# Altium schematic sheet Sensors.SchDoc(Sensors)
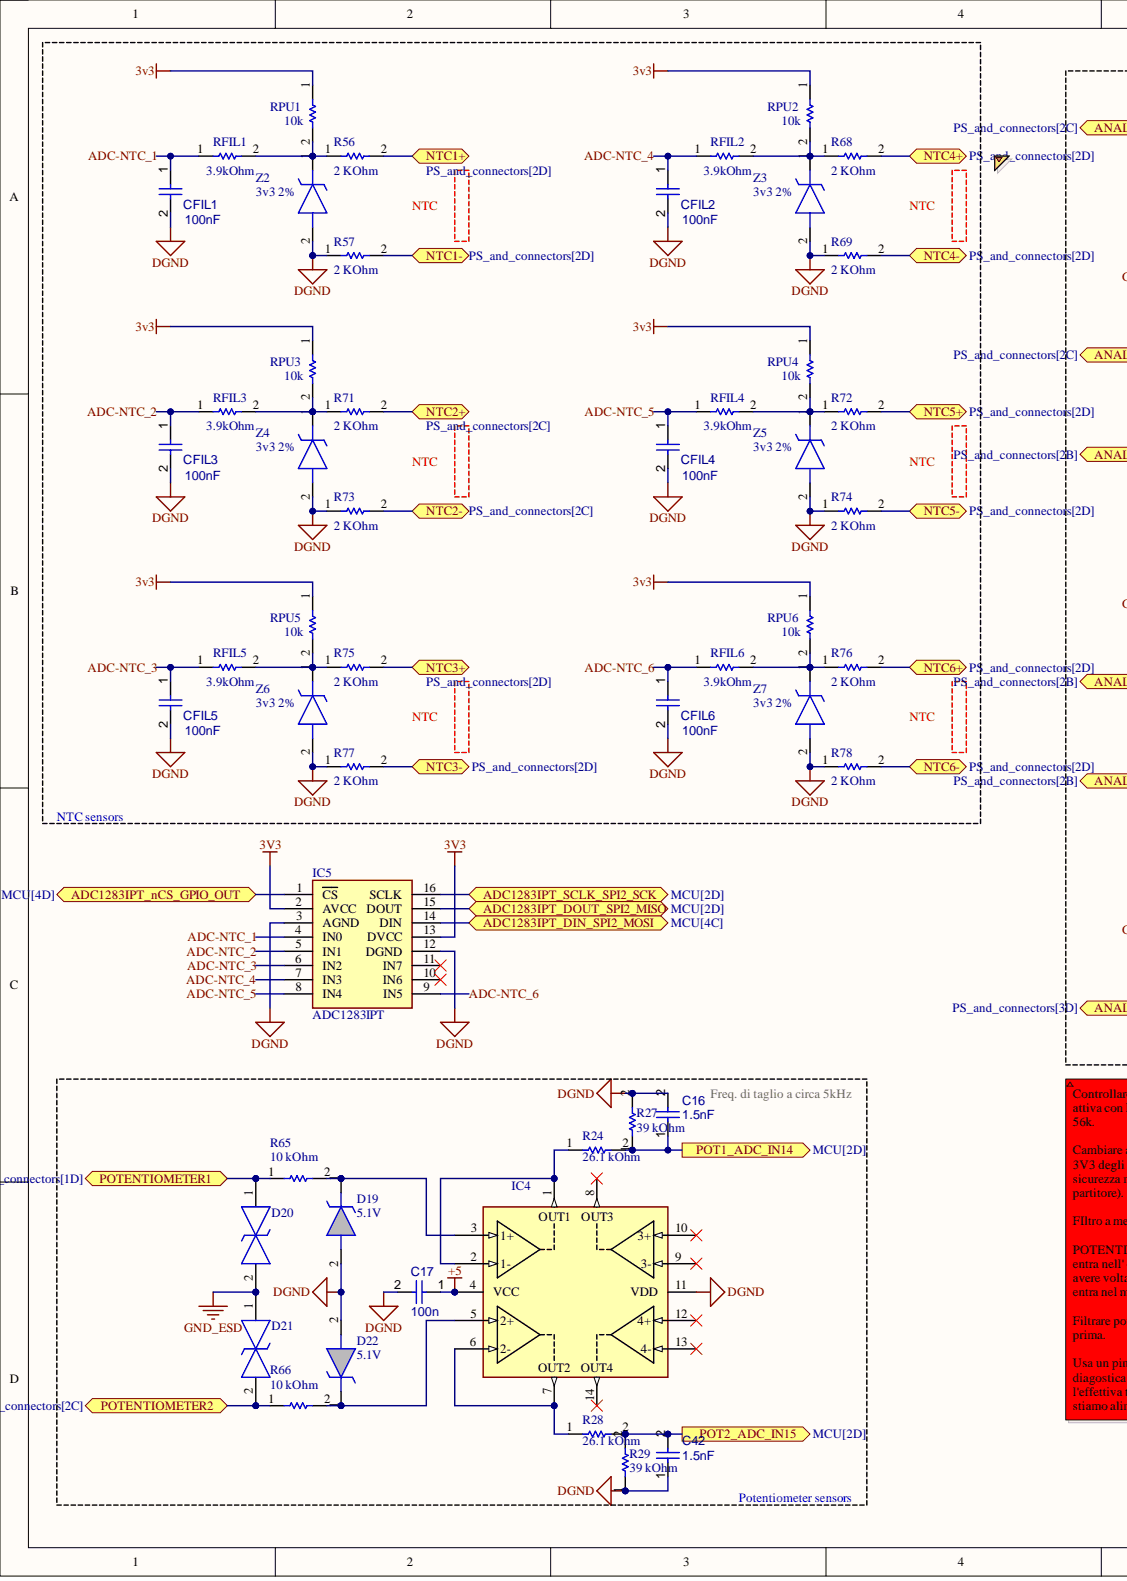
[diagram p0: Sensors.SchDoc, left]
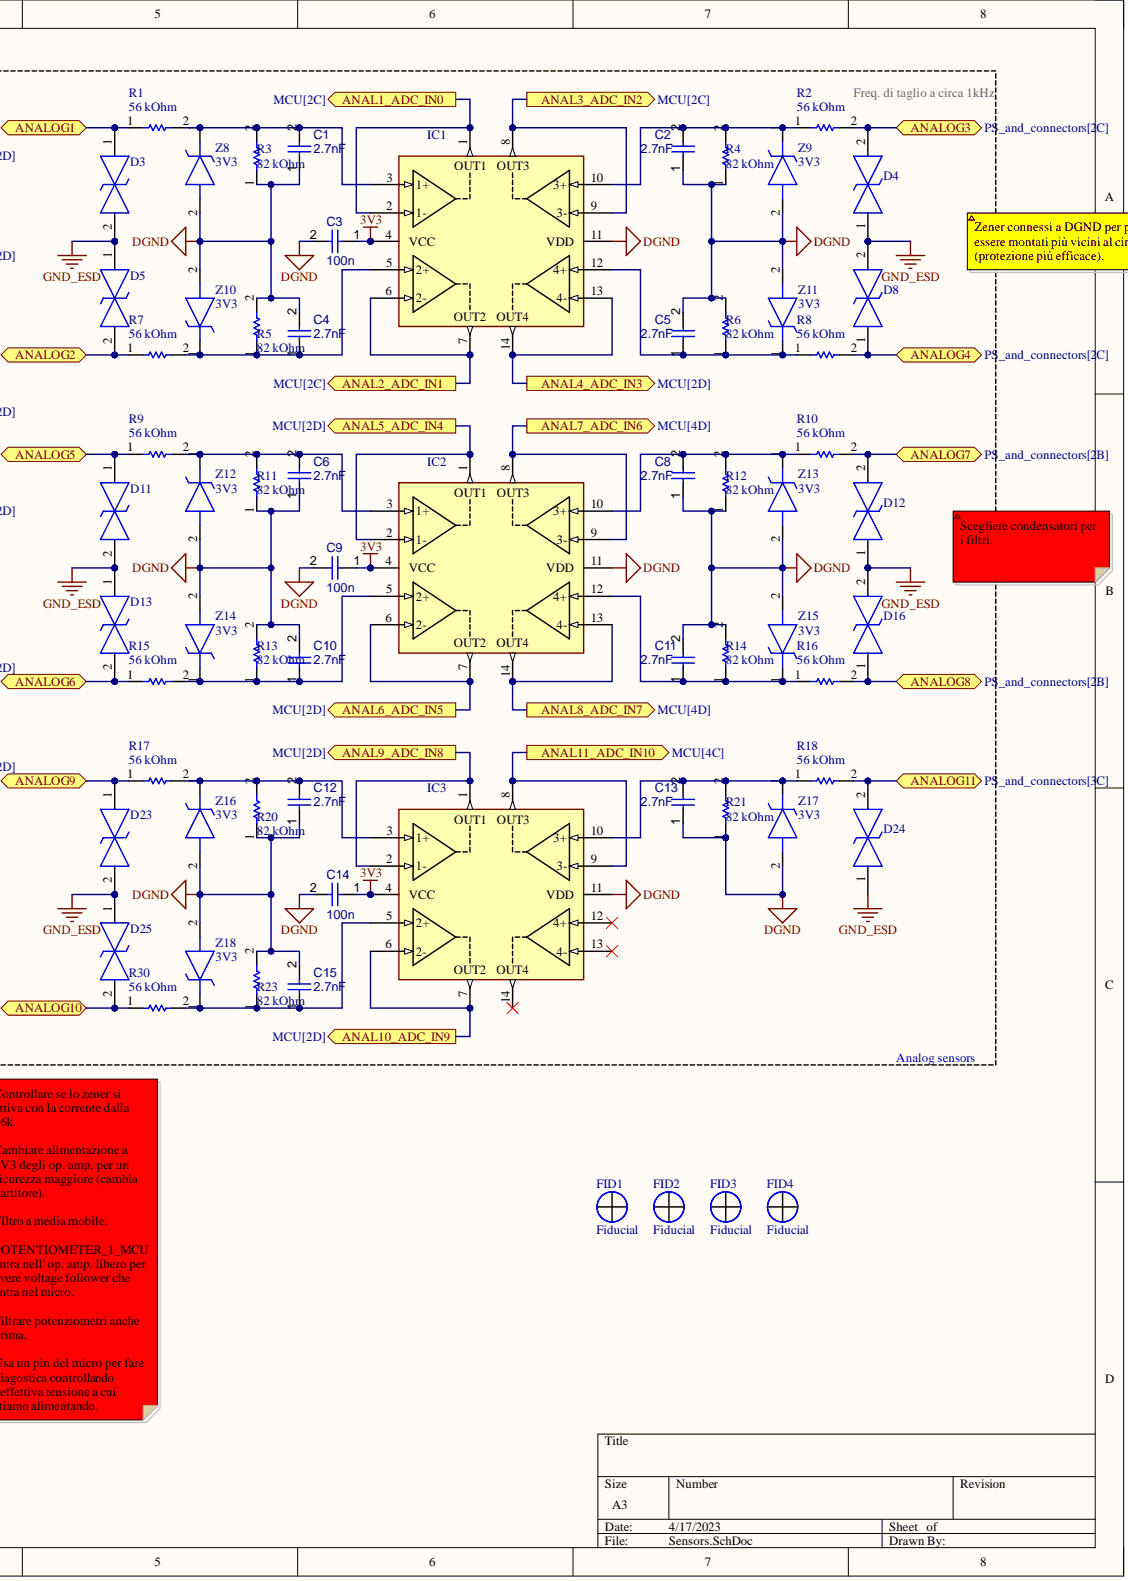
[diagram p1: Sensors.SchDoc, right]

## Parts
C1  2.7nF
C2  2.7nF
C3  100n
C4  2.7nF
C5  2.7nF
C6  2.7nF
C8  2.7nF
C9  100n
C10  2.7nF
C11  2.7nF
C12  2.7nF
C13  2.7nF
C14  100n
C15  2.7nF
C16  1.5nF
C17  100n
C42  1.5nF
CFIL1  100nF
CFIL2  100nF
CFIL3  100nF
CFIL4  100nF
CFIL5  100nF
CFIL6  100nF
D3  SMAJ30CA-TR  (value not drawn)
D4  SMAJ30CA-TR  (value not drawn)
D5  SMAJ30CA-TR  (value not drawn)
D8  SMAJ30CA-TR  (value not drawn)
D11  SMAJ30CA-TR  (value not drawn)
D12  SMAJ30CA-TR  (value not drawn)
D13  SMAJ30CA-TR  (value not drawn)
D16  SMAJ30CA-TR  (value not drawn)
D19  5.1V
D20  SMAJ30CA-TR  (value not drawn)
D21  SMAJ30CA-TR  (value not drawn)
D22  5.1V
D23  SMAJ30CA-TR  (value not drawn)
D24  SMAJ30CA-TR  (value not drawn)
D25  SMAJ30CA-TR  (value not drawn)
FID1  Fiducial
FID2  Fiducial
FID3  Fiducial
FID4  Fiducial
IC1  TSV324IDT  (value not drawn)
IC2  TSV324IDT  (value not drawn)
IC3  TSV324IDT  (value not drawn)
IC4  TSV324IDT  (value not drawn)
IC5  ADC1283IPT
R1  56 kOhm
R2  56 kOhm
R3  82 kOhm
R4  82 kOhm
R5  82 kOhm
R6  82 kOhm
R7  56 kOhm
R8  56 kOhm
R9  56 kOhm
R10  56 kOhm
R11  82 kOhm
R12  82 kOhm
R13  82 kOhm
R14  82 kOhm
R15  56 kOhm
R16  56 kOhm
R17  56 kOhm
R18  56 kOhm
R20  82 kOhm
R21  82 kOhm
R23  82 kOhm
R24  26.1 kOhm
R27  39 kOhm
R28  26.1 kOhm
R29  39 kOhm
R30  56 kOhm
R56  2 KOhm
R57  2 KOhm
R65  10 kOhm
R66  10 kOhm
R68  2 KOhm
R69  2 KOhm
R71  2 KOhm
R72  2 KOhm
R73  2 KOhm
R74  2 KOhm
R75  2 KOhm
R76  2 KOhm
R77  2 KOhm
R78  2 KOhm
RFIL1  3.9kOhm
RFIL2  3.9kOhm
RFIL3  3.9kOhm
RFIL4  3.9kOhm
RFIL5  3.9kOhm
RFIL6  3.9kOhm
RPU1  10k
RPU2  10k
RPU3  10k
RPU4  10k
RPU5  10k
RPU6  10k
Z2  3v3 2%
Z3  3v3 2%
Z4  3v3 2%
Z5  3v3 2%
Z6  3v3 2%
Z7  3v3 2%
Z8  3V3
Z9  3V3
Z10  3V3
Z11  3V3
Z12  3V3
Z13  3V3
Z14  3V3
Z15  3V3
Z16  3V3
Z17  3V3
Z18  3V3

## Ports
ADC1283IPT_DIN_SPI2_MOSI, ADC1283IPT_DOUT_SPI2_MISO, ADC1283IPT_nCS_GPIO_OUT, ADC1283IPT_SCLK_SPI2_SCK, ANAL1_ADC_IN0, ANAL2_ADC_IN1, ANAL3_ADC_IN2, ANAL4_ADC_IN3, ANAL5_ADC_IN4, ANAL6_ADC_IN5, ANAL7_ADC_IN6, ANAL8_ADC_IN7, ANAL9_ADC_IN8, ANAL10_ADC_IN9, ANAL11_ADC_IN10, ANALOG1, ANALOG2, ANALOG3, ANALOG4, ANALOG5, ANALOG6, ANALOG7, ANALOG8, ANALOG9, ANALOG10, ANALOG11, NTC1+, NTC1-, NTC2+, NTC2-, NTC3+, NTC3-, NTC4+, NTC4-, NTC5+, NTC5-, NTC6+, NTC6-, POT1_ADC_IN14, POT2_ADC_IN15, POTENTIOMETER1, POTENTIOMETER2

## Nets
3v3: C3.1 C9.1 C14.1 IC1.4(VCC) IC2.4(VCC) IC3.4(VCC) IC5.2(AVCC) IC5.13(DVCC) RPU1.1 RPU2.1 RPU3.1 RPU4.1 RPU5.1 RPU6.1
+5: C17.1 IC4.4(VCC)
DGND: C1.1 C2.1 C3.2 C4.2 C5.2 C6.1 C8.1 C9.2 C10.2 C11.2 C12.1 C13.1 C14.2 C15.2 C16.2 C17.2 C42.1 CFIL1.2 CFIL2.2 CFIL3.2 CFIL4.2 CFIL5.2 CFIL6.2 D19.2 D22.2 IC1.11(VDD) IC2.11(VDD) IC3.11(VDD) IC4.11(VDD) IC5.3(AGND) IC5.12(DGND) R3.1 R4.1 R5.2 R6.2 R11.1 R12.1 R13.2 R14.2 R20.1 R21.1 R23.2 R27.2 R29.1 R57.1 R69.1 R73.1 R74.1 R77.1 R78.1 Z2.2 Z3.2 Z4.2 Z5.2 Z6.2 Z7.2 Z8.2 Z9.2 Z10.2 Z11.2 Z12.2 Z13.2 Z14.2 Z15.2 Z16.2 Z17.2 Z18.2
GND_ESD: D3.2 D4.1 D5.1 D8.2 D11.2 D12.1 D13.1 D16.2 D20.2 D21.1 D23.2 D24.1 D25.1
ADC1283IPT_DIN_SPI2_MOSI: IC5.14(DIN) ; port ADC1283IPT_DIN_SPI2_MOSI
ADC1283IPT_DOUT_SPI2_MISO: IC5.15(DOUT) ; port ADC1283IPT_DOUT_SPI2_MISO
ADC1283IPT_nCS_GPIO_OUT: IC5.1(CS) ; port ADC1283IPT_nCS_GPIO_OUT
ADC1283IPT_SCLK_SPI2_SCK: IC5.16(SCLK) ; port ADC1283IPT_SCLK_SPI2_SCK
ADC-NTC_1: CFIL1.1 IC5.4(IN0) RFIL1.1
ADC-NTC_2: CFIL3.1 IC5.5(IN1) RFIL3.1
ADC-NTC_3: CFIL5.1 IC5.6(IN2) RFIL5.1
ADC-NTC_4: CFIL2.1 IC5.7(IN3) RFIL2.1
ADC-NTC_5: CFIL4.1 IC5.8(IN4) RFIL4.1
ADC-NTC_6: CFIL6.1 IC5.9(IN5) RFIL6.1
ANAL1_ADC_IN0: IC1.1 IC1.2(1-) ; port ANAL1_ADC_IN0
ANAL2_ADC_IN1: IC1.6(2-) IC1.7 ; port ANAL2_ADC_IN1
ANAL3_ADC_IN2: IC1.8 IC1.9(3-) ; port ANAL3_ADC_IN2
ANAL4_ADC_IN3: IC1.13(4-) IC1.14 ; port ANAL4_ADC_IN3
ANAL5_ADC_IN4: IC2.1 IC2.2(1-) ; port ANAL5_ADC_IN4
ANAL6_ADC_IN5: IC2.6(2-) IC2.7 ; port ANAL6_ADC_IN5
ANAL7_ADC_IN6: IC2.8 IC2.9(3-) ; port ANAL7_ADC_IN6
ANAL8_ADC_IN7: IC2.13(4-) IC2.14 ; port ANAL8_ADC_IN7
ANAL9_ADC_IN8: IC3.1 IC3.2(1-) ; port ANAL9_ADC_IN8
ANAL10_ADC_IN9: IC3.6(2-) IC3.7 ; port ANAL10_ADC_IN9
ANAL11_ADC_IN10: IC3.8 IC3.9(3-) ; port ANAL11_ADC_IN10
ANALOG1: D3.1 R1.1 ; port ANALOG1
ANALOG2: D5.2 R7.1 ; port ANALOG2
ANALOG3: D4.2 R2.2 ; port ANALOG3
ANALOG4: D8.1 R8.2 ; port ANALOG4
ANALOG5: D11.1 R9.1 ; port ANALOG5
ANALOG6: D13.2 R15.1 ; port ANALOG6
ANALOG7: D12.2 R10.2 ; port ANALOG7
ANALOG8: D16.1 R16.2 ; port ANALOG8
ANALOG9: D23.1 R17.1 ; port ANALOG9
ANALOG10: D25.2 R30.1 ; port ANALOG10
ANALOG11: D24.2 R18.2 ; port ANALOG11
POT1_ADC_IN14: C16.1 R24.2 R27.1 ; port POT1_ADC_IN14
POT2_ADC_IN15: C42.2 R28.2 R29.2 ; port POT2_ADC_IN15
POTENTIOMETER1: D20.1 R65.1 ; port POTENTIOMETER1
POTENTIOMETER2: D21.2 R66.1 ; port POTENTIOMETER2
NetC1_2: C1.2 IC1.3(1+) R1.2 R3.2 Z8.1
NetC2_2: C2.2 IC1.10(3+) R2.1 R4.2 Z9.1
NetC4_1: C4.1 IC1.5(2+) R5.1 R7.2 Z10.1
NetC5_1: C5.1 IC1.12(4+) R6.1 R8.1 Z11.1
NetC6_2: C6.2 IC2.3(1+) R9.2 R11.2 Z12.1
NetC8_2: C8.2 IC2.10(3+) R10.1 R12.2 Z13.1
NetC10_1: C10.1 IC2.5(2+) R13.1 R15.2 Z14.1
NetC11_1: C11.1 IC2.12(4+) R14.1 R16.1 Z15.1
NetC12_2: C12.2 IC3.3(1+) R17.2 R20.2 Z16.1
NetC13_2: C13.2 IC3.10(3+) R18.1 R21.2 Z17.1
NetC15_1: C15.1 IC3.5(2+) R23.1 R30.2 Z18.1
NetD19_1: D19.1 IC4.3(1+) R65.2
NetD22_1: D22.1 IC4.5(2+) R66.2
NetIC4_1: IC4.1 IC4.2(1-) R24.1
NetIC4_6: IC4.6(2-) IC4.7 R28.1
NetR56_1: R56.1 RFIL1.2 RPU1.2 Z2.1
NetR56_2: R56.2
NetR57_2: R57.2
NetR68_1: R68.1 RFIL2.2 RPU2.2 Z3.1
NetR68_2: R68.2
NetR69_2: R69.2
NetR71_1: R71.1 RFIL3.2 RPU3.2 Z4.1
NetR71_2: R71.2
NetR72_1: R72.1 RFIL4.2 RPU4.2 Z5.1
NetR72_2: R72.2
NetR73_2: R73.2
NetR74_2: R74.2
NetR75_1: R75.1 RFIL5.2 RPU5.2 Z6.1
NetR75_2: R75.2
NetR76_1: R76.1 RFIL6.2 RPU6.2 Z7.1
NetR76_2: R76.2
NetR77_2: R77.2
NetR78_2: R78.2

Not connected: IC3 12(4+) 13(4-) 14; IC4 8 9(3-) 10(3+) 12(4+) 13(4-) 14; IC5 10(IN6) 11(IN7)

## Library data of the parts
C1 C2 C4-C6 C8 C10-C13 C15 = CMP-001-00085-2: CL21B272KBANNNC; Tech: SMD; Component Kind: Standard; ComponentLink1Description: Website; Package: 0805; Library Name: EMEA- Squadra Corse PoliTO-  Politecnico di Torino
C3 C9 C14 C17 = CMP-001-00085-2: VJ0805Y104MXATW1BC; Tech: SMD; Component Kind: Standard; ComponentLink1Description: Website; Package: 0805; Library Name: EMEA- Squadra Corse PoliTO-  Politecnico di Torino
C16 C42 = CMP-001-00085-2: 885012207061; Tech: SMD; Component Kind: Standard; ComponentLink1Description: Website; Package: 0805; Library Name: EMEA- Squadra Corse PoliTO-  Politecnico di Torino
CFIL1-CFIL6 = CMP-001-00085-2: VJ0805Y104KXBAT; Tech: SMD; Component Kind: Standard; ComponentLink1Description: Website; Package: 0805; Library Name: EMEA- Squadra Corse PoliTO-  Politecnico di Torino
D3-D5 D8 D11-D13 D16 D20 D21 D23-D25 = CMP-004-000005-1: TVS Diode Bi-Directional SMAJ30CA-TR 64.3V; Manufacturer_Name: STMicroelectronics; Arrow Part Number: SMAJ30CA-TR; Arrow Price/Stock: https://www.arrow.com/en/products/smaj30ca-tr/stmicroelectronics?region=nac; Component Kind: Standard; Datasheet Link: https://www.st.com/resource/en/datasheet/smaj10ca.pdf; Design Item ID: SMAJ30CA-TR; Height: 2.65mm; Manufacturer_Part_Number: SMAJ30CA-TR; Mouser Part Number: 511-SMAJ30CA-TR; Mouser Price/Stock: https://www.mouser.co.uk/ProductDetail/STMicroelectronics/SMAJ30CA-TR?qs=0TJjK1waviDY0EkLaKH12g%3D%3D; Source: SamacSys.SchLib; Library Name: EMEA- Squadra Corse PoliTO-  Politecnico di Torino
D19 D22 = CMP-002-000008-1: MMSZ5231C-E3-08; Tech: SMD; Component Kind: Standard; Package: SOD-123-2; Library Name: EMEA- Squadra Corse PoliTO-  Politecnico di Torino
FID1-FID4 = CMP-00028-00007-10: Component Kind: Standard; Library Name: EMEA- Squadra Corse PoliTO-  Politecnico di Torino
IC1-IC4 = CMP-007-000004-2: Manufacturer_Name: STMicroelectronics; Arrow Part Number: TSV324IDT; Arrow Price/Stock: https://www.arrow.com/en/products/tsv324idt/stmicroelectronics; Component Kind: Standard; Datasheet Link: http://www.st.com/st-web-ui/static/active/en/resource/technical/document/datasheet/CD00063292.pdf; Description: TSV324IDT; Design Item ID: TSV324IDT; Height: 1.75mm; Manufacturer_Part_Number: TSV324IDT; Mouser Part Number: 511-TSV324IDT; Mouser Price/Stock: https://www.mouser.com/Search/Refine.aspx?Keyword=511-TSV324IDT; Source: SamacSys.SchLib; Library Name: EMEA- Squadra Corse PoliTO-  Politecnico di Torino
IC5 = CMP-007-000007-1: 8-Channel; Manufacturer_Name: STMicroelectronics; Component Kind: Standard; Datasheet Link: https://www.st.com/resource/en/datasheet/adc1283.pdf; Design Item ID: ADC1283IPT; Height: 1.2mm; Manufacturer_Part_Number: ADC1283IPT; Source: SamacSys.SchLib; Library Name: EMEA- Squadra Corse PoliTO-  Politecnico di Torino
R1 R2 R7-R10 R15-R18 R30 = CMP-009-00179-4: RT0603BRD0756KL; Tech: SMD; Component Kind: Standard; ComponentLink1Description: Website; Package: 0603; Library Name: EMEA- Squadra Corse PoliTO-  Politecnico di Torino
R3-R6 R11-R14 R20 R21 R23 = CMP-009-00179-4: RT0603BRD0782KL; Tech: SMD; Component Kind: Standard; ComponentLink1Description: Website; Package: 0603; Library Name: EMEA- Squadra Corse PoliTO-  Politecnico di Torino
R24 R28 = CMP-009-00179-4: RT0603BRD0726K1L; Tech: SMD; Component Kind: Standard; ComponentLink1Description: Website; Package: 0603; Library Name: EMEA- Squadra Corse PoliTO-  Politecnico di Torino
R27 R29 = CMP-009-00179-4: RT0603BRD0739KL; Tech: SMD; Component Kind: Standard; ComponentLink1Description: Website; Package: 0603; Library Name: EMEA- Squadra Corse PoliTO-  Politecnico di Torino
R56 R57 R68 R69 R71-R78 = CMP-009-00181-1: AC1206FR-7W2KL; Tech: SMD; Component Kind: Standard; Package: 1206; Library Name: EMEA- Squadra Corse PoliTO-  Politecnico di Torino
R65 R66 = CMP-009-00179-4: RC1206FR-0710KL; Tech: SMD; Component Kind: Standard; ComponentLink1Description: Website; Package: 0603; Library Name: EMEA- Squadra Corse PoliTO-  Politecnico di Torino
RFIL1-RFIL6 = CMP-009-00177-13: CRCW08053K90FKEAHP; Tech: SMD; Component Kind: Standard; ComponentLink1Description: Website; Package: 0805; Library Name: EMEA- Squadra Corse PoliTO-  Politecnico di Torino
RPU1-RPU6 = CMP-009-00181-1: RC1206FR-0710KL; Tech: SMD; Component Kind: Standard; Package: 1206; Library Name: EMEA- Squadra Corse PoliTO-  Politecnico di Torino
Z2-Z7 = CMP-011-000001-1: MMSZ5226C-HE3-08; Manufacturer_Name: Vishay; Arrow Part Number: MMSZ5226C-HE3-08; Arrow Price/Stock: https://www.arrow.com/en/products/mmsz5226c-he3-08/vishay?region=nac; Component Kind: Standard; Datasheet Link: https://www.arrow.com/en/products/mmsz5226c-he3-08/vishay; Design Item ID: MMSZ5226C-HE3-08; Height: 1.35mm; Manufacturer_Part_Number: MMSZ5226C-HE3-08; Mouser Part Number: 78-MMSZ5226C-HE3-08; Mouser Price/Stock: https://www.mouser.co.uk/ProductDetail/Vishay-Semiconductors/MMSZ5226C-HE3-08?qs=ESFF34UzhwgdfTXz%2Fhjhlg%3D%3D; Source: SamacSys.SchLib; Library Name: EMEA- Squadra Corse PoliTO-  Politecnico di Torino
Z8-Z18 = CMP-011-000003-1: MMSZ5226C-E3-08; Manufacturer_Name: Vishay; Arrow Part Number: MMSZ5226C-E3-08; Arrow Price/Stock: https://www.arrow.com/en/products/mmsz5226c-e3-08/vishay?region=nac; Component Kind: Standard; Datasheet Link: https://www.vishay.com/docs/85774/mmsz5225.pdf; Design Item ID: MMSZ5226C-E3-08; Height: 1.35mm; Manufacturer_Part_Number: MMSZ5226C-E3-08; Mouser Part Number: 78-MMSZ5226C-E3-08; Mouser Price/Stock: https://www.mouser.co.uk/ProductDetail/Vishay-Semiconductors/MMSZ5226C-E3-08?qs=S660mHfF5o3pnFKmbKLjqA%3D%3D; Source: SamacSys.SchLib; Library Name: EMEA- Squadra Corse PoliTO-  Politecnico di Torino
